# Altium schematic sheet OpenBLC_MCP73831.SchDoc(OpenBLC_MCP73831)
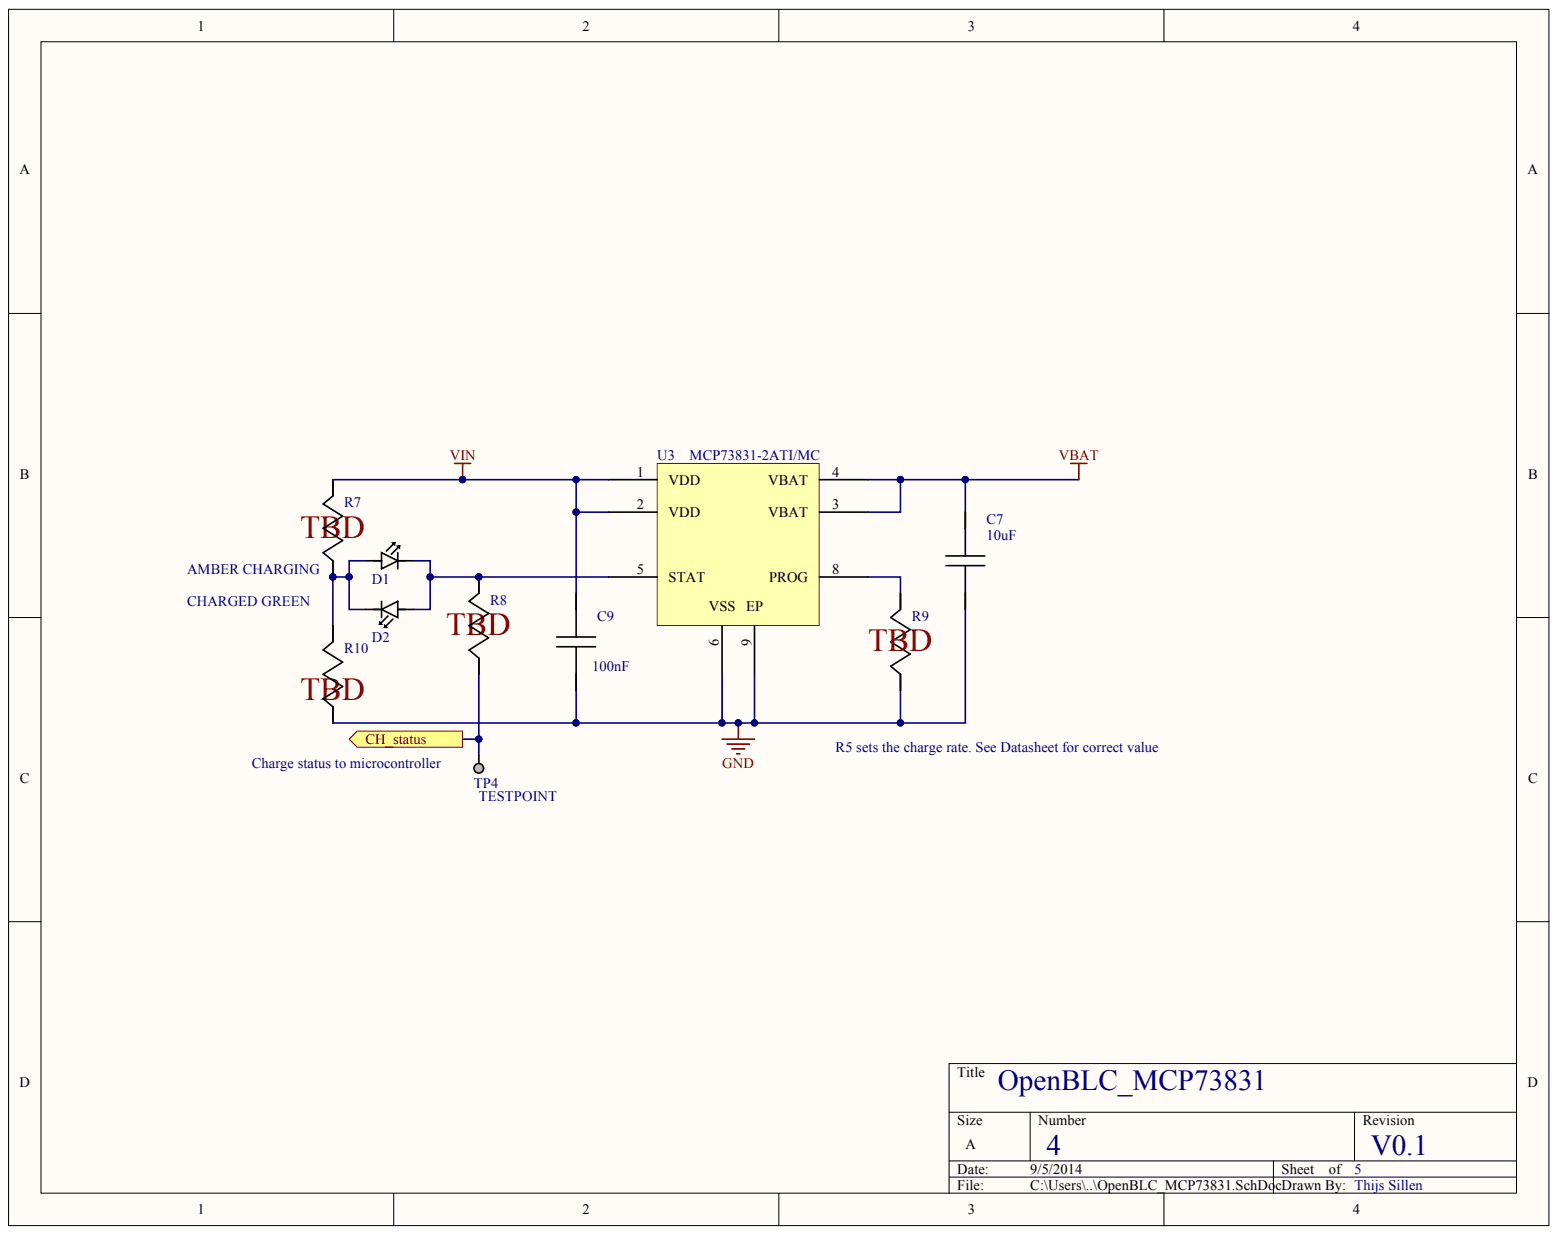
[diagram p0: OpenBLC_MCP73831.SchDoc]

## Parts
C7  Capacitance: 10uF  [0805_CAP]  (hidden comment: GRM21BR61A106KE19L)
C9  =Value: 100nF  [0805_CAP]  (hidden comment: 0805_CAP)
D1  0603LED_YE  [0603 _DIODE_YE]  (value not drawn)
D2  0603LED_GR  [0603 _DIODE_GR]  (value not drawn)
R7  0805_RES  [0805_RES]  (value not drawn)
R8  0805_RES  [0805_RES]  (value not drawn)
R9  0805_RES  [0805_RES]  (value not drawn)
R10  0805_RES  [0805_RES]  (value not drawn)
TP4  TESTPOINT  [Via_TP]
U3  MCP73831-2ATI/MC  [PQFN50P300X200X5_HS-9N]

## Ports
CH_STATUS

## Nets
GND: C7.2 C9.2 R9.2 R10.2 U3.6 U3.9(EP)
VBAT: C7.1 U3.3(VBAT) U3.4(VBAT)
VIN: C9.1 R7.1 U3.1(VDD) U3.2(VDD)
NetD1_1: D1.1 D2.2 R8.1 U3.5(STAT)
NetD1_2: D1.2 D2.1 R7.2 R10.1
NetR8_2: R8.2 TP4.1 ; port CH_STATUS
NetR9_1: R9.1 U3.8(PROG)

## Library data of the parts
C7 = GRM21BR61A106KE19L: MURATA - GRM21BR61A106KE19L - CAPACITOR, CERA, 10UF, 10%, 10V, 10%, SMD; Ceramic Capacitor Case: 0805 ^2012 Metric]; Dielectric Characteristic: X5; Product Range: MURATA - GRM Series; Voltage Rating: 10; Capacitance Tolerance: ± 10%; Component Kind: Standard; ComponentLink1Description: http://www.farnell.com/datasheets/608985.pdf; ComponentLink1URL: http://www.farnell.com/datasheets/608985.pdf; Library Name: Schlib1.SchLib; Manufacturer: MURATA; Manufacturer Part Number: GRM21BR61A106KE19L; MSL: -; Pricing 1: 1=0.042, 250=0.039, 500=0.034, 1000=0.031, 5000=0.022 (EUR); RoHS: YES; Stock 1: 20106; Supplier 1: Farnell; Supplier Part Number 1: 2396552
TP4 = TESTPOINT: TESTPOINT SCOPE GRABBER; Manufacturer: Keystone Electronics; Manufacturer Part Number: 5010; Reel: TH; Component Kind: Standard; Library Name: vh_altium_library.intLib; Supplier 1: Digi-Key; Supplier Part Number 1: 5010K-ND
U3 = MCP73831-2ATI/MC: Batterijbeheer Li-Ion/Li-Poly Charg Mgnt 4.2V Vreg out; Manufacturer: Microchip Technology; Batterijtype: Li-Ion, Li-Poly; Fabrikant: Microchip; Maximale bedrijfstemperatuur: + 85 C; Minimale bedrijfstemperatuur: - 40 C; Montagetype: SMD/SMT; Productcategorie: Battery Management; Standaard pakket hoeveelheid: 150; Uitgangsstroom: 15 mA to 500 mA; Verpakken: Tube; Verpakking / doos: DFN; Category: Batterijbeheer; Component Kind: Standard; ComponentLink1Description: http://www.mouser.com/ds/2/268/21984e-53484.pdf; ComponentLink1URL: http://www.mouser.com/ds/2/268/21984e-53484.pdf; Library Name: Schlib1.SchLib; Manufacturer Part Number: MCP73831-2ATI/MC; Merk: Microchip Technology; Minimum Order Quantity: 1; Pricing 1: 1=0.512, 10=0.472, 25=0.392, 100=0.360 (EUR); Producttype: Charge Management; Reeling: False; RoHS: RoHS Compliant; RoHS - Mouser: Y; Stock 1: 0; Supplier 1: Mouser; Supplier Part Number 1: 579-MCP73831-2ATI/MC; Uitgangspanning: 4.2 V
C9 = 0805_CAP: Component Kind: Standard; Library Name: Schlib1.SchLib
D1 = 0603LED_YE: Component Kind: Standard; Library Name: Schlib1.SchLib
D2 = 0603LED_GR: Component Kind: Standard; Library Name: Schlib1.SchLib
R7-R10 = 0805_RES: Component Kind: Standard; Library Name: Schlib1.SchLib
